# Altium schematic sheet MCU.SchDoc
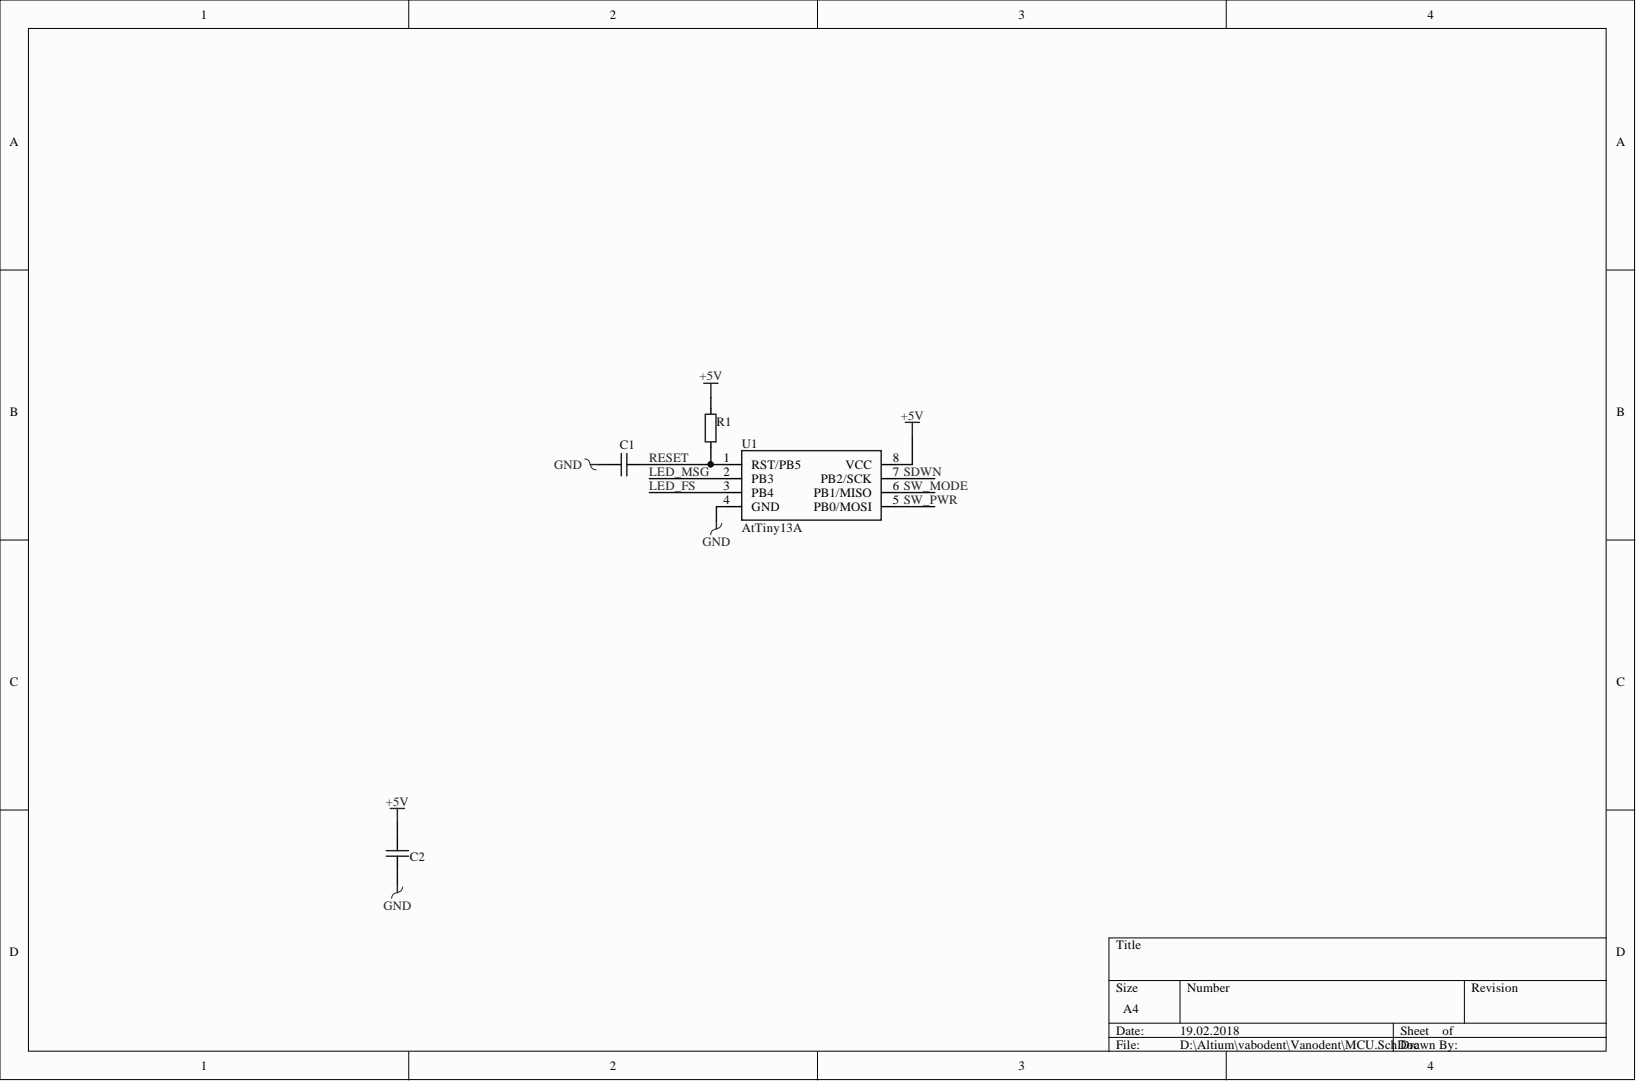
[diagram p0: MCU.SchDoc]

## Parts
C1  (no value)  [SMD_0805]
C2  (no value)  [SMD_0805]
R1  (no value)  [SMD_0805]
U1  AtTiny13A

## Nets
+5V: C2.1 R1.2 U1.8(VCC)
GND: C1.1 C2.2 U1.4(GND)
LED_FS: U1.3(PB4)
LED_MSG: U1.2(PB3)
RESET: C1.2 R1.1 U1.1(RST/PB5)
SDWN: U1.7(PB2/SCK)
SW_MODE: U1.6(PB1/MISO)
SW_PWR: U1.5(PB0/MOSI)

## Library data of the parts
C1 C2 = C_NONE_POLAR: None polar capacitor; Component Kind: Standard; Library Name: DISCRETE.SchLib
R1 = RES: Resistor; Component Kind: Standard; Library Name: DISCRETE.SchLib
U1 = AtTiny13A: Component Kind: Standard; Library Name: ICs.SchLib
